# Altium schematic sheet BM20_Rev0_Communications.SchDoc
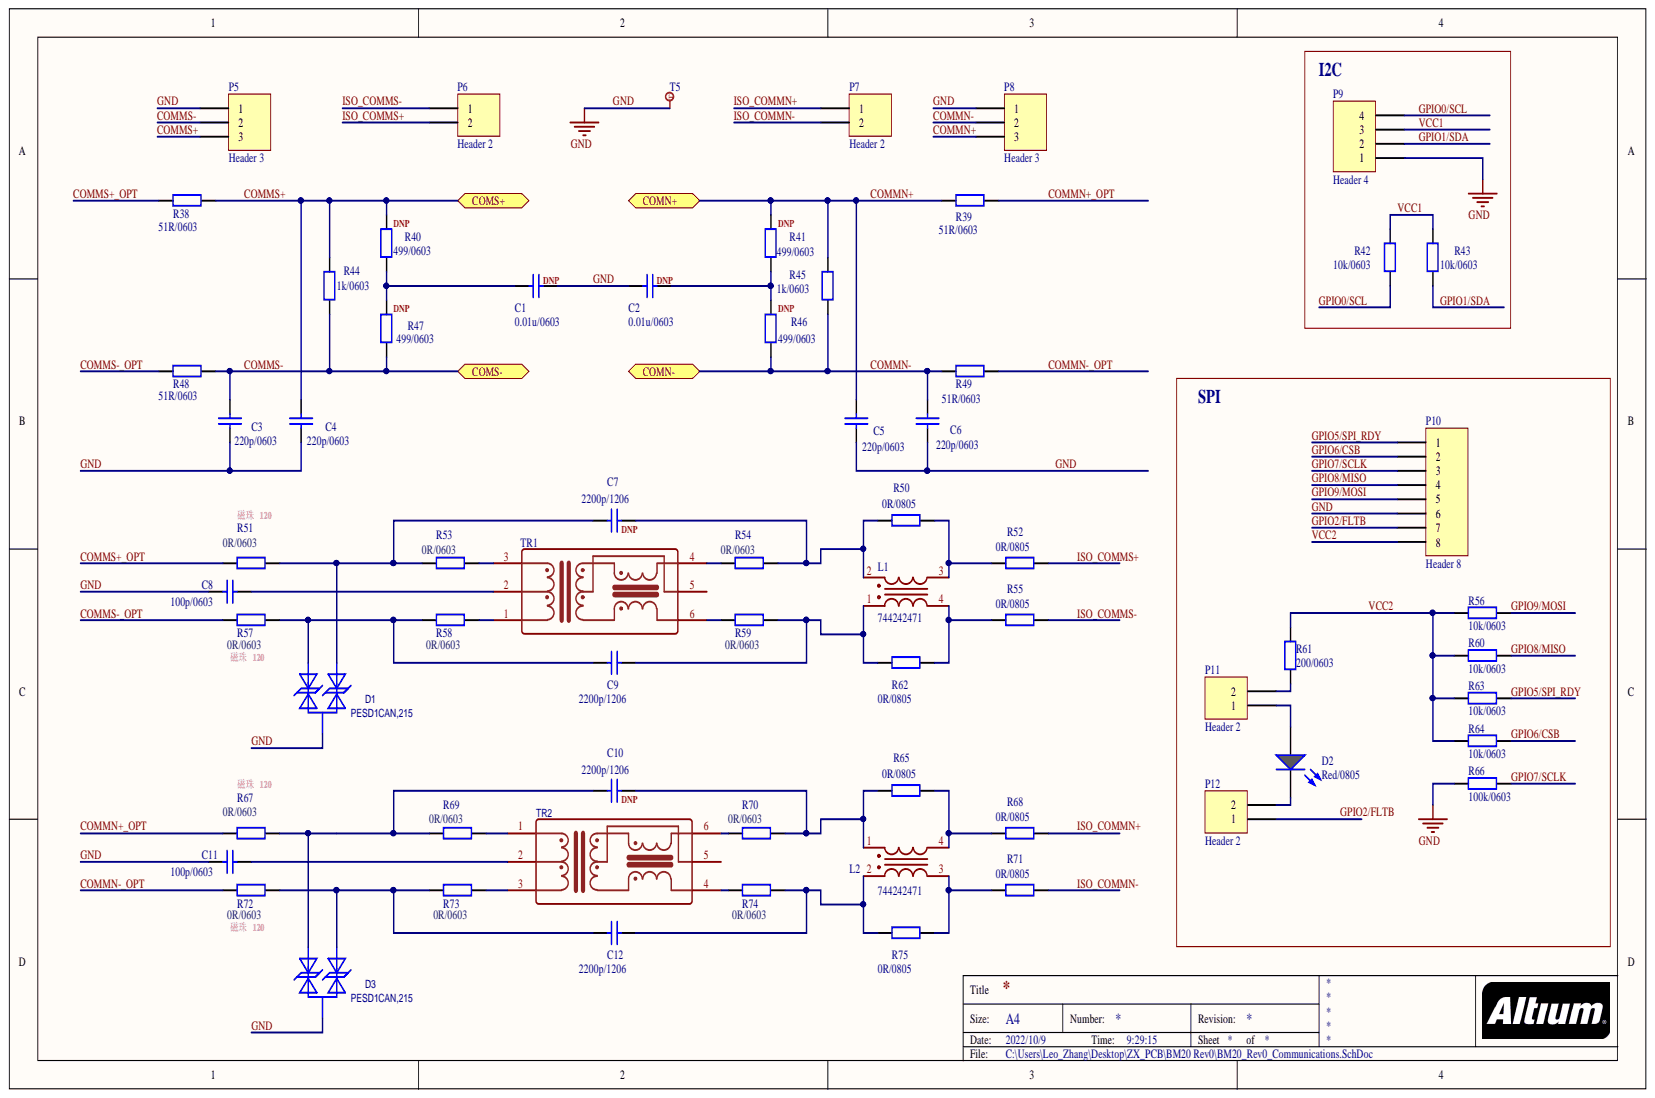
[diagram p0: BM20_Rev0_Communications.SchDoc]

## Parts
C1  0.01u/0603  [0603_C]
C2  0.01u/0603  [0603_C]
C3  220p/0603  [0603_C]
C4  220p/0603  [0603_C]
C5  220p/0603  [0603_C]
C6  220p/0603  [0603_C]
C7  2200p/1206  [1206_C]
C8  100p/0603  [0603_C]
C9  2200p/1206  [1206_C]
C10  2200p/1206  [1206_C]
C11  100p/0603  [0603_C]
C12  2200p/1206  [1206_C]
D1  PESD1CAN,215  [SOT-23]
D2  Red/0805  [0805_LED]
D3  PESD1CAN,215  [SOT-23]
L1  744242471  [CM Inductor]
L2  744242471  [CM Inductor]
P5  Header 3  [HDR1X3]
P6  Header 2  [MX3.0mm-2P]
P7  Header 2  [MX3.0mm-2P]
P8  Header 3  [HDR1X3]
P9  Header 4  [HDR1X4]
P10  Header 8  [HDR1X8]
P11  Header 2  [HDR1X2]
P12  Header 2  [HDR1X2]
R38  51R/0603  [0603_R]
R39  51R/0603  [0603_R]
R40  499/0603  [0603_R]
R41  499/0603  [0603_R]
R42  10k/0603  [0603_R]
R43  10k/0603  [0603_R]
R44  1k/0603  [0603_R]
R45  1k/0603  [0603_R]
R46  499/0603  [0603_R]
R47  499/0603  [0603_R]
R48  51R/0603  [0603_R]
R49  51R/0603  [0603_R]
R50  0R/0805  [0805_R]
R51  0R/0603  [0603_R]
R52  0R/0805  [0805_R]
R53  0R/0603  [0603_R]
R54  0R/0603  [0603_R]
R55  0R/0805  [0805_R]
R56  10k/0603  [0603_R]
R57  0R/0603  [0603_R]
R58  0R/0603  [0603_R]
R59  0R/0603  [0603_R]
R60  10k/0603  [0603_R]
R61  200/0603  [0603_R]
R62  0R/0805  [0805_R]
R63  10k/0603  [0603_R]
R64  10k/0603  [0603_R]
R65  0R/0805  [0805_R]
R66  100k/0603  [0603_R]
R67  0R/0603  [0603_R]
R68  0R/0805  [0805_R]
R69  0R/0603  [0603_R]
R70  0R/0603  [0603_R]
R71  0R/0805  [0805_R]
R72  0R/0603  [0603_R]
R73  0R/0603  [0603_R]
R74  0R/0603  [0603_R]
R75  0R/0805  [0805_R]
T5  Test  [TP_GND]  (value not drawn)
TR1  ISO_Trans with choke_1  (value not drawn)
TR2  (no value)  [Isolated Transformer]

## Ports
COMN+, COMN-, COMS+, COMS-

## Nets
COMMN+: C5.1 P8.3 R39.2 R41.2 R45.2
COMMN+_OPT: R39.1 R67.2
COMMN-: C6.1 P8.2 R45.1 R46.1 R49.2
COMMN-_OPT: R49.1 R72.2
COMMS+: C4.1 P5.3 R38.1 R40.2 R44.2
COMMS+_OPT: R38.2 R51.2
COMMS-: C3.1 P5.2 R44.1 R47.1 R48.1
COMMS-_OPT: R48.2 R57.2
GND: C1.1 C2.2 C3.2 C4.2 C5.2 C6.2 C8.1 C11.1 D1.3 D3.3 P5.1 P8.1 P9.1 P10.6 R66.2 T5.0
GPIO0/SCL: P9.4 R42.1
GPIO1/SDA: P9.2 R43.1
GPIO2/FLTB: P10.7 P12.1
GPIO5/SPI_RDY: P10.1 R63.1
GPIO6/CSB: P10.2 R64.1
GPIO7/SCLK: P10.3 R66.1
GPIO8/MISO: P10.4 R60.1
GPIO9/MOSI: P10.5 R56.1
ISO_COMMN+: P7.1 R68.1
ISO_COMMN-: P7.2 R71.1
ISO_COMMS+: P6.2 R52.1
ISO_COMMS-: P6.1 R55.1
VCC1: P9.3 R42.2 R43.2
VCC2: P10.8 R56.2 R60.2 R61.2 R63.2 R64.2
NetC1_2: C1.2 R40.1 R47.2
NetC2_1: C2.1 R41.1 R46.2
NetC7_1: C7.1 D1.1 R51.1 R53.2
NetC7_2: C7.2 L1.2 R50.2 R54.1
NetC8_2: C8.2 TR1.2
NetC9_1: C9.1 D1.2 R57.1 R58.2
NetC9_2: C9.2 L1.1 R59.1 R62.2
NetC10_1: C10.1 D3.2 R67.1 R69.2
NetC10_2: C10.2 L2.1 R65.2 R70.1
NetC11_2: C11.2 TR2.2
NetC12_1: C12.1 D3.1 R72.1 R73.2
NetC12_2: C12.2 L2.2 R74.1 R75.2
NetD2_A: D2.A P11.1
NetD2_K: D2.K P12.2
NetL1_3: L1.3 R50.1 R52.2
NetL1_4: L1.4 R55.2 R62.1
NetL2_3: L2.3 R71.2 R75.1
NetL2_4: L2.4 R65.1 R68.2
NetP11_2: P11.2 R61.1
NetR53_1: R53.1 TR1.3
NetR54_2: R54.2 TR1.4
NetR58_1: R58.1 TR1.1
NetR59_2: R59.2 TR1.6
NetR69_1: R69.1 TR2.1
NetR70_2: R70.2 TR2.6
NetR73_1: R73.1 TR2.3
NetR74_2: R74.2 TR2.4

Not connected: TR1 5; TR2 5

## Library data of the parts
C1-C12 = capacitor: 贴片电容; Component Kind: Standard; Library Name: Schlib1.SchLib
D1 D3 = PESD1CAN_C343993: Component Kind: Standard; Library Name: BM02 Rev0.SchLib
D2 = LED: 发光二极管; Component Kind: Standard; Library Name: Schlib1.SchLib
L1 = ISO_INDUCTOR_1: Component Kind: Standard; Library Name: BM20 Rev0.SchLib
L2 = ISO_INDUCTOR: Component Kind: Standard; Library Name: BM02 Rev0.SchLib
P5 P8 = Header 3: Header, 3-Pin; Component Kind: Standard; Library Name: Miscellaneous Connectors.IntLib; Signal Integrity: Connector
P6 P7 P11 P12 = Header 2: Header, 2-Pin; Component Kind: Standard; Library Name: Miscellaneous Connectors.IntLib; Signal Integrity: Connector
P9 = Header 4: I2C Connecctor; Component Kind: Standard; LatestRevisionDate: 17-Jul-2002; LatestRevisionNote: Re-released for DXP Platform.; Library Name: Miscellaneous Connectors.IntLib; Signal Integrity: Connector; Publisher: Altium Limited
P10 = Header 8: SPI  Connector; Component Kind: Standard; LatestRevisionDate: 17-Jul-2002; LatestRevisionNote: Re-released for DXP Platform.; Library Name: Miscellaneous Connectors.IntLib; Signal Integrity: Connector; Publisher: Altium Limited
R38-R75 = Resistor: 贴片电阻; Component Kind: Standard; Library Name: Schlib1.SchLib
TR2 = SM91502ALE: BOM_Manufacturer: BOURNS; Contributor: LCSC; BOM_JLCPCB Part Class: Extended Part; BOM_Manufacturer Part: SM91502ALE; BOM_Supplier: LCSC; BOM_Supplier Part: C915690; Component Kind: Standard; spicePre: D; spiceSymbolName: SM91502ALE
T5 = Test: Component Kind: Standard; Library Name: 17S.SchLib
TR1 = ISO_Trans with choke_1: Component Kind: Standard; Library Name: BM20 Rev0.SchLib
